# Altium schematic sheet ArcadeRpiSmartShield 33 Pi interface.SchDoc
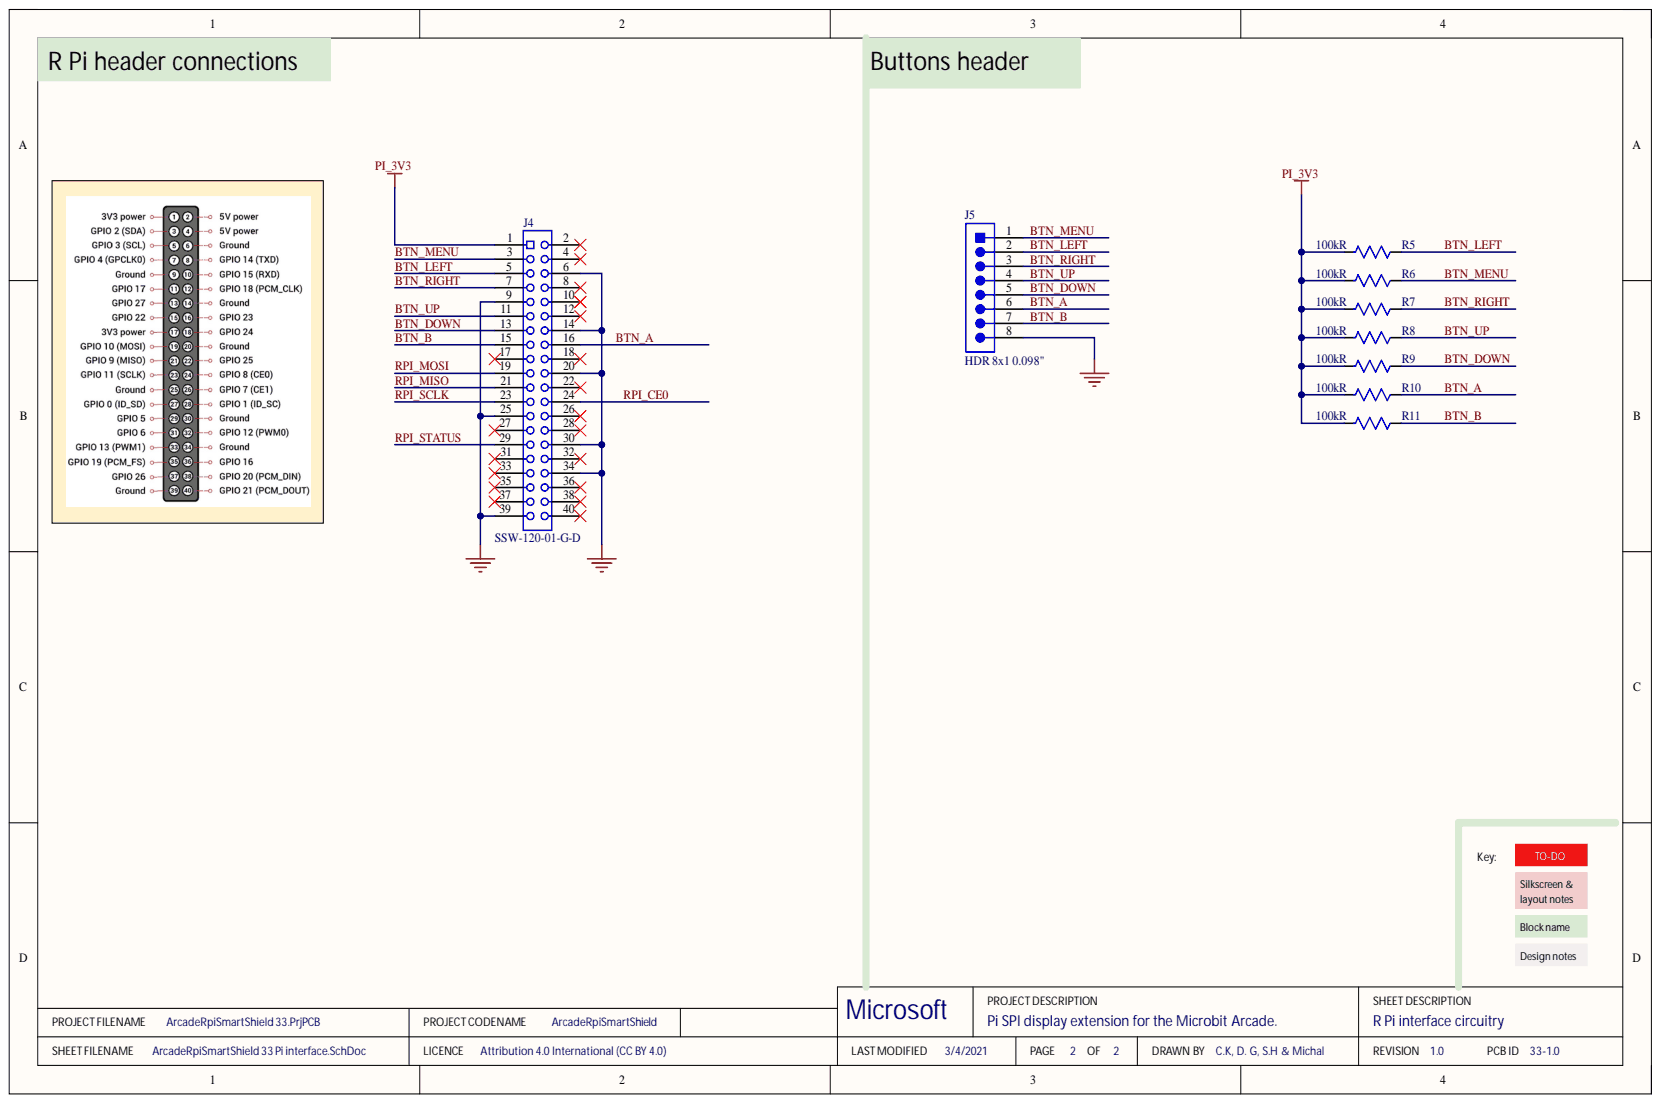
[diagram p0: ArcadeRpiSmartShield 33 Pi interface.SchDoc]

## Parts
J4  SSW-120-01-G-D  [SAMTEC-SSW-120-01-G-D]
J5  HDR 8x1 0.098"  [B8B-XH-A(LF)(SN)]
R5  Resistance: 100kR  [R0402 - Without outline]  (hidden comment: RC0402FR-07100KL)
R6  Resistance: 100kR  [R0402 - Without outline]  (hidden comment: RC0402FR-07100KL)
R7  Resistance: 100kR  [R0402 - Without outline]  (hidden comment: RC0402FR-07100KL)
R8  Resistance: 100kR  [R0402 - Without outline]  (hidden comment: RC0402FR-07100KL)
R9  Resistance: 100kR  [R0402 - Without outline]  (hidden comment: RC0402FR-07100KL)
R10  Resistance: 100kR  [R0402 - Without outline]  (hidden comment: RC0402FR-07100KL)
R11  Resistance: 100kR  [R0402 - Without outline]  (hidden comment: RC0402FR-07100KL)

## Nets
GND: J4.6 J4.9 J4.14 J4.20 J4.25 J4.30 J4.34 J4.39 J5.8
PI_3V3: J4.1 R5.1 R6.1 R7.1 R8.1 R9.1 R10.1 R11.1
BTN_A: J4.16 J5.6 R10.2
BTN_B: J4.15 J5.7 R11.2
BTN_DOWN: J4.13 J5.5 R9.2
BTN_LEFT: J4.5 J5.2 R5.2
BTN_MENU: J4.3 J5.1 R6.2
BTN_RIGHT: J4.7 J5.3 R7.2
BTN_UP: J4.11 J5.4 R8.2
RPI_CE0: J4.24
RPI_MISO: J4.21
RPI_MOSI: J4.19
RPI_SCLK: J4.23
RPI_STATUS: J4.29

Not connected: J4 2 4 8 10 12 17 18 22 26 27 28 31 32 33 35 36 37 38 40

## Library data of the parts
J4 = SSW-120-01-G-D: 20 position 2 row (40 way) 0.1" TH socket; Manufacturer: Samtec; Connector Type: Receptacle,Socket; Contact Resistance: 10mR; Current Rating: 6.9A; Gender: Receptacle; Mating Cycles: 100; Max Voltage Rating (AC): 550V; Number of Contacts: 40; Pitch: 2.54mm; Row Spacing: 0.1inch; Color: Black; Component Kind: Standard; ComponentLink1Description: samtec catalogue page online; ComponentLink1URL: http://suddendocs.samtec.com/catalog_english/ssw_th.pdf; ComponentLink2Description: samtec drawing online; ComponentLink2URL: http://suddendocs.samtec.com/prints/ssw-1xx-xx-xxx-x-xx-xxx-xx-mkt.pdf; Contact Material: Bronze; Contact Plating: Gold; Depth: 4.95mm; Housing Color: Black; Housing Material: Polymer; Lead Free: Lead Free; Lead Pitch: 2.54mm; Length: 51.31mm; Library Name: Samtec.SchLib; Manufacturer Part Number: SSW-120-01-G-D; Max Current Rating: 6.9A; Max Operating Temperature: 125°C; Min Operating Temperature: -55°C; Mount: Through Hole; Number of Positions: 40; Number of Rows: 2; Orientation: Straight; Packaging: Bulk; Pricing 1: 1=5.36000, 10=5.14900, 25=4.72040, 50=4.50580, 100=4.29120, 250=3.75480, 500=3.64752 (USD); Radiation Hardening: No; REACH SVHC: No SVHC; RoHS: Yes; Stock 1: 0; Supplier 1: Digi-Key; Supplier 2: Farnell; Supplier 3: Mouser; Supplier 4: RSComponents; Supplier Part Number 1: SAM1208-20-ND; Supplier Part Number 2: 1668397; Supplier Part Number 3: 200-SSW12001GD; Supplier Part Number 4: 7655802; Termination: Solder; Voltage Rating: 550V
J5 = B8B-XH-A(LF)(SN): CONN HEADER VERT 8POS 2.5MM; Manufacturer: JST; Connector Type: Male Pin; Contact Resistance: 20mR; Current Rating: 3A; Insulation Resistance: 1GR; Max Voltage Rating (AC): 250V; Number of Contacts: 8; Wire/Cable Gauge: 22AWG; Category: FFC / FPC; Color: Natural; Component Kind: Standard; ComponentLink1Description: Online datasheet; ComponentLink1URL: https://datasheet.ciiva.com/26894/getdatasheetpartid-440408-26894817.pdf; Contact Material: Brass; Contact Plating: Tin,Copper,Lead; Depth: 5.75mm; Fastening Type: Detent; Housing Color: White; Housing Material: Polyamide; Lead Free: Lead Free; Lead Pitch: 2.5mm; Length: 22.4mm; Library Name: JST.SchLib; Manufacturer Part Number: B8B-XH-A(LF)(SN); Max Current Rating: 3A; Max Operating Temperature: 85°C; Min Operating Temperature: -25°C; Mount: Through Hole; Number of Positions: 8; Number of Rows: 1; Orientation: Straight; Packaging: Bulk; Pitch: 2.5mm; Pricing 1: 1=0.360000, 10=0.341000, 100=0.238700, 500=0.204580, 1000=0.170480, 5000=0.146130, 10000=0.136390 (USD); Pricing 2: 10=0.27000, 100=0.18800, 500=0.16200, 1000=0.13500, 2500=0.12500, 5000=0.11500 (GBP); REACH SVHC: No SVHC; RoHS: Yes; Stock 1: 6017; Stock 2: 11541; Supplier 1: Digi-Key; Supplier 2: Farnell; Supplier Part Number 1: 455-2251-ND; Supplier Part Number 2: 1516284; Termination: Press-Fit; Voltage Rating: 250V; Voltage Rating (AC): 250V; Checked By: ?; Created By: D. Gakure
R5-R11 = RC0402FR-07100KL: RES SMD 100K OHM 1% 1/16W 0402; Manufacturer: Yageo; Max Power Dissipation: 63mW; Temperature Coefficient: 100ppm/°C; Voltage Rating: 50V; Case Code (Imperial): 0402; Case Code (Metric): 1005; Case/Package: 0402; Category: Resistors; Component Kind: Standard; ComponentLink2Description: Online datasheet; ComponentLink2URL: https://datasheet.ciiva.com/2453/pyu-r-int-thick-7-2453361.pdf; Depth: 0.5mm; Features: Moisture Resistant; Height: 0.016inch; Lead Free: Lead Free; Length: 0.039inch; Library Name: Yageo.SchLib; Manufacturer Part Number: RC0402FR-07100KL; Max Operating Temperature: 155°C; Military Standard: Not; Min Operating Temperature: -55°C; Number of Terminations: 2; Package Quantity: 1; Packaging: Tape and Reel; Power Rating: 63mW; Pricing 1: 1=0.10000, 10=0.01400, 100=0.00580, 1000=0.00262, 2500=0.00228, 5000=0.00188 (USD); Pricing 2: 1=0.10000, 10=0.01200, 100=0.00400, 1000=0.00400, 2500=0.00400, 10000=0.00300 (USD); Pricing 3: 1=0.10200, 25=0.01220, 100=0.01200, 250=0.01020, 500=0.00890 (GBP); REACH SVHC: No SVHC; Resistor Type: Thick Film; RoHS: Yes; Stock 1: 5819191; Stock 2: 4458847; Stock 3: 19392; Supplier 1: Digi-Key; Supplier 2: Mouser; Supplier 3: Farnell; Supplier Part Number 1: 311-100KLRCT-ND; Supplier Part Number 2: 603-RC0402FR-07100KL; Supplier Part Number 3: 2131798; Termination: SMD/SMT; Tolerance: 1%; Width: 0.02inch; Working Voltage: 50V; Checked By: ?; Created By: DG
